# Altium schematic sheet PW_DB2.SchDoc
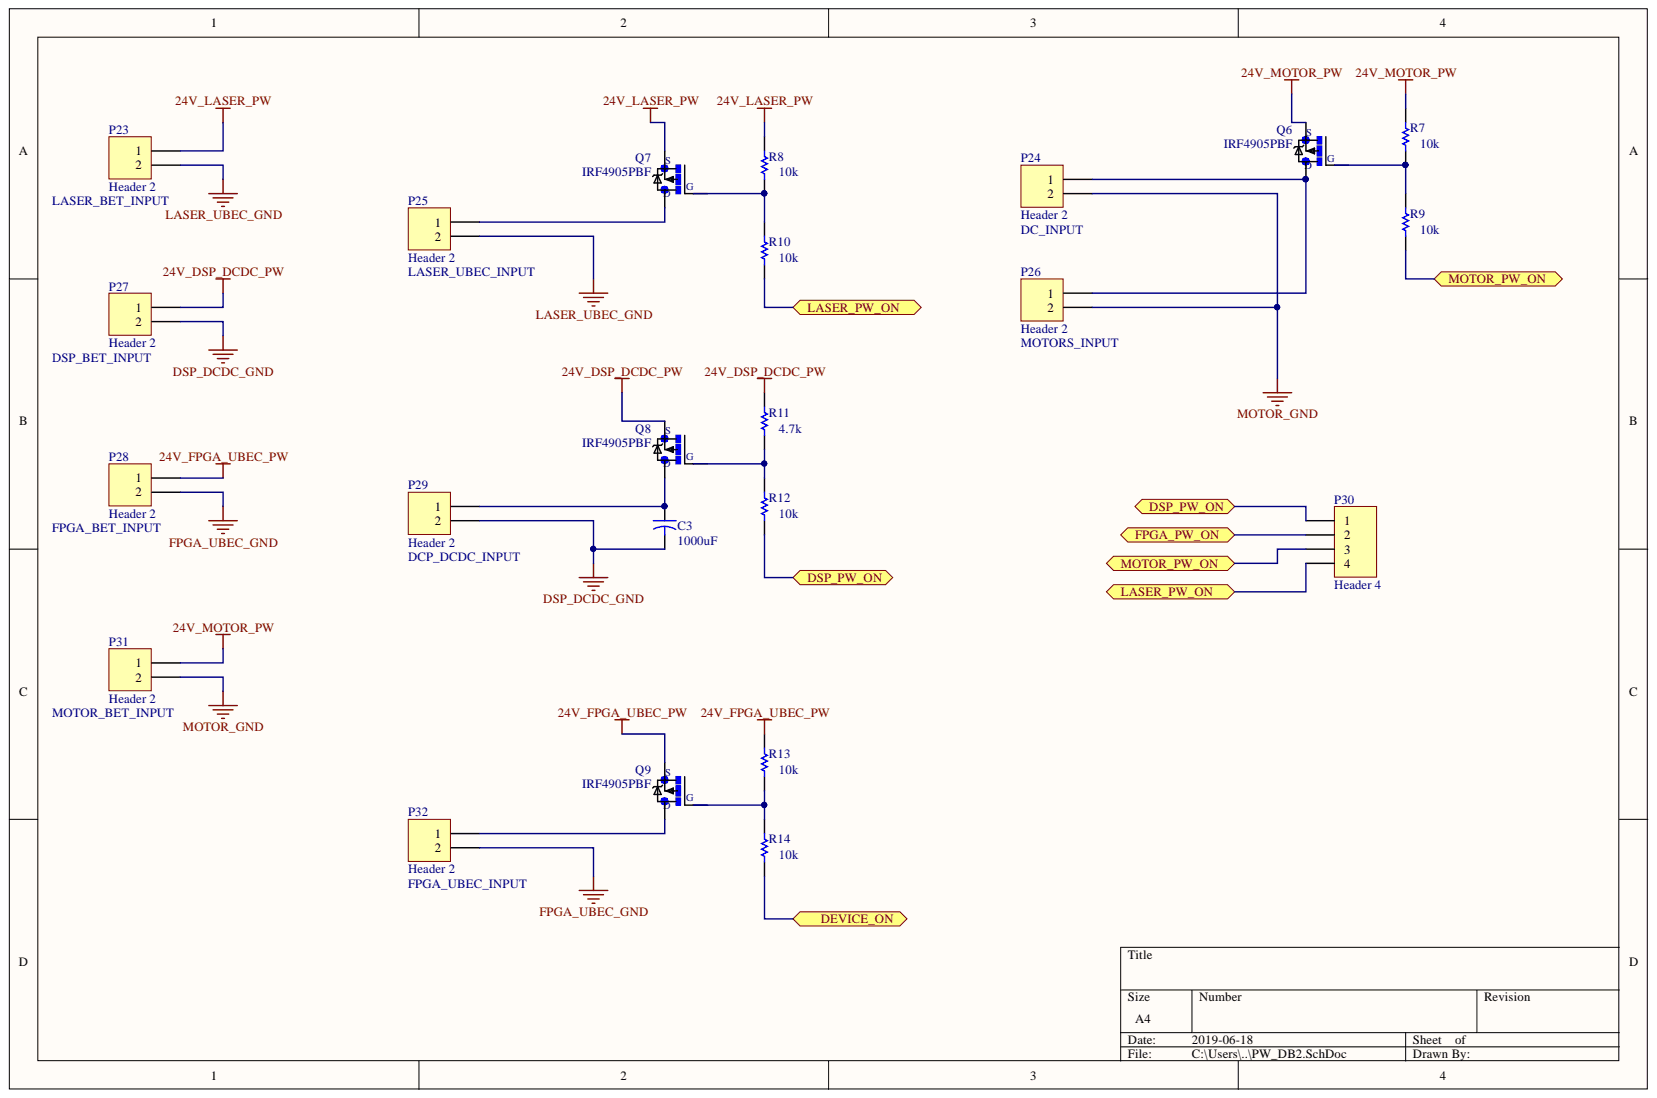
[diagram p0: PW_DB2.SchDoc]

## Parts
C3  value 1000uF  [CAPR5-4X5]  (hidden comment: Cap2)
P23  Header 2  [HDR1X2]
P24  Header 2  [HDR1X2]
P25  Header 2  [HDR1X2]
P26  Header 2  [HDR1X2]
P27  Header 2  [HDR1X2]
P28  Header 2  [HDR1X2]
P29  Header 2  [HDR1X2]
P30  Header 4  [HDR1X4]
P31  Header 2  [HDR1X2]
P32  Header 2  [HDR1X2]
Q6  IRF4905PBF  [*TO220BH_IRF4905PBF(Primary)]
Q7  IRF4905PBF  [*TO220BH_IRF4905PBF(Primary)]
Q8  IRF4905PBF  [*TO220BH_IRF4905PBF(Primary)]
Q9  IRF4905PBF  [*TO220BH_IRF4905PBF(Primary)]
R7  value 10k  [AXIAL-0.3]  (hidden comment: Res1)
R8  value 10k  [AXIAL-0.3]  (hidden comment: Res1)
R9  value 10k  [AXIAL-0.3]  (hidden comment: Res1)
R10  value 10k  [AXIAL-0.3]  (hidden comment: Res1)
R11  value 4.7k  [AXIAL-0.3]  (hidden comment: Res1)
R12  value 10k  [AXIAL-0.3]  (hidden comment: Res1)
R13  value 10k  [AXIAL-0.3]  (hidden comment: Res1)
R14  value 10k  [AXIAL-0.3]  (hidden comment: Res1)

## Ports
DEVICE_ON, DSP_PW_ON, FPGA_PW_ON, LASER_PW_ON, MOTOR_PW_ON

## Nets
24V_DSP_DCDC_PW: P27.1 Q8.S R11.2
24V_FPGA_UBEC_PW: P28.1 Q9.S R13.2
24V_LASER_PW: P23.1 Q7.S R8.2
24V_MOTOR_PW: P31.1 Q6.S R7.2
DSP_DCDC_GND: C3.2 P27.2 P29.2
FPGA_UBEC_GND: P28.2 P32.2
LASER_UBEC_GND: P23.2 P25.2
MOTOR_GND: P24.2 P26.2 P31.2
DEVICE_ON: R14.1 ; port DEVICE_ON
DSP_PW_ON: P30.1 R12.1 ; port DSP_PW_ON
FPGA_PW_ON: P30.2 ; port FPGA_PW_ON
LASER_PW_ON: P30.4 R10.1 ; port LASER_PW_ON
MOTOR_PW_ON: P30.3 R9.1 ; port MOTOR_PW_ON
NetC3_1: C3.1 P29.1 Q8.D
NetP24_1: P24.1 P26.1 Q6.D
NetP25_1: P25.1 Q7.D
NetP32_1: P32.1 Q9.D
NetR7_1: R7.1 R9.2
NetR8_1: R8.1 R10.2
NetR11_1: R11.1 R12.2
NetR13_1: R13.1 R14.2

Not connected: Q6 G; Q7 G; Q8 G; Q9 G

## Library data of the parts
C3 = Cap2: Capacitor; Component Kind: Standard; Library Name: Miscellaneous Devices.IntLib; PackageDescription: Capacitor; 2 Leads; PackageReference: CAPR5-4X5; Published: 23-Sep-2002; Signal Integrity: Cap; Simulation: CAP; Publisher: Altium Limited
P23-P29 P31 P32 = Header 2: Header, 2-Pin; Component Kind: Standard; LatestRevisionDate: 17-Jul-2002; LatestRevisionNote: Re-released for DXP Platform.; Library Name: Miscellaneous Connectors.IntLib; Signal Integrity: Connector; Publisher: Altium Limited
P30 = Header 4: Header, 4-Pin; Component Kind: Standard; LatestRevisionDate: 17-Jul-2002; LatestRevisionNote: Re-released for DXP Platform.; Library Name: Miscellaneous Connectors.IntLib; Signal Integrity: Connector; Publisher: Altium Limited
Q6-Q9 = IRF4905PBF: MOSFET, Power; P-Ch; VDSS -55V; RDS_ON_ 0.02Ohm; ID -74A; TO-220AB; PD 200W; VGS +/-20V; MF: Infineon; Availability: Unavailable; Component Kind: Standard; Library Name: IRF4905PBF.SchLib; MP: IRF4905PBF; Package: TO-220 Infineon; Price: None; SnapEDA_Link: https://www.snapeda.com/parts/IRF4905PBF/Infineon%20Technologies/view-part/?ref=snap
R7-R14 = Res1: Resistor; Component Kind: Standard; LatestRevisionDate: 17-Jul-2002; LatestRevisionNote: Re-released for DXP Platform.; Library Name: Miscellaneous Devices.IntLib; PackageDescription: Axial Device, Thru-Hole; 2 Leads; 0.3 in Pin Spacing; PackageReference: AXIAL-0.3; Published: 8-Jun-2000; Signal Integrity: Res; Simulation: RESISTOR; Publisher: Altium Limited
